# Altium schematic sheet LM2596_DC_TO_DC_schematic.SchDoc
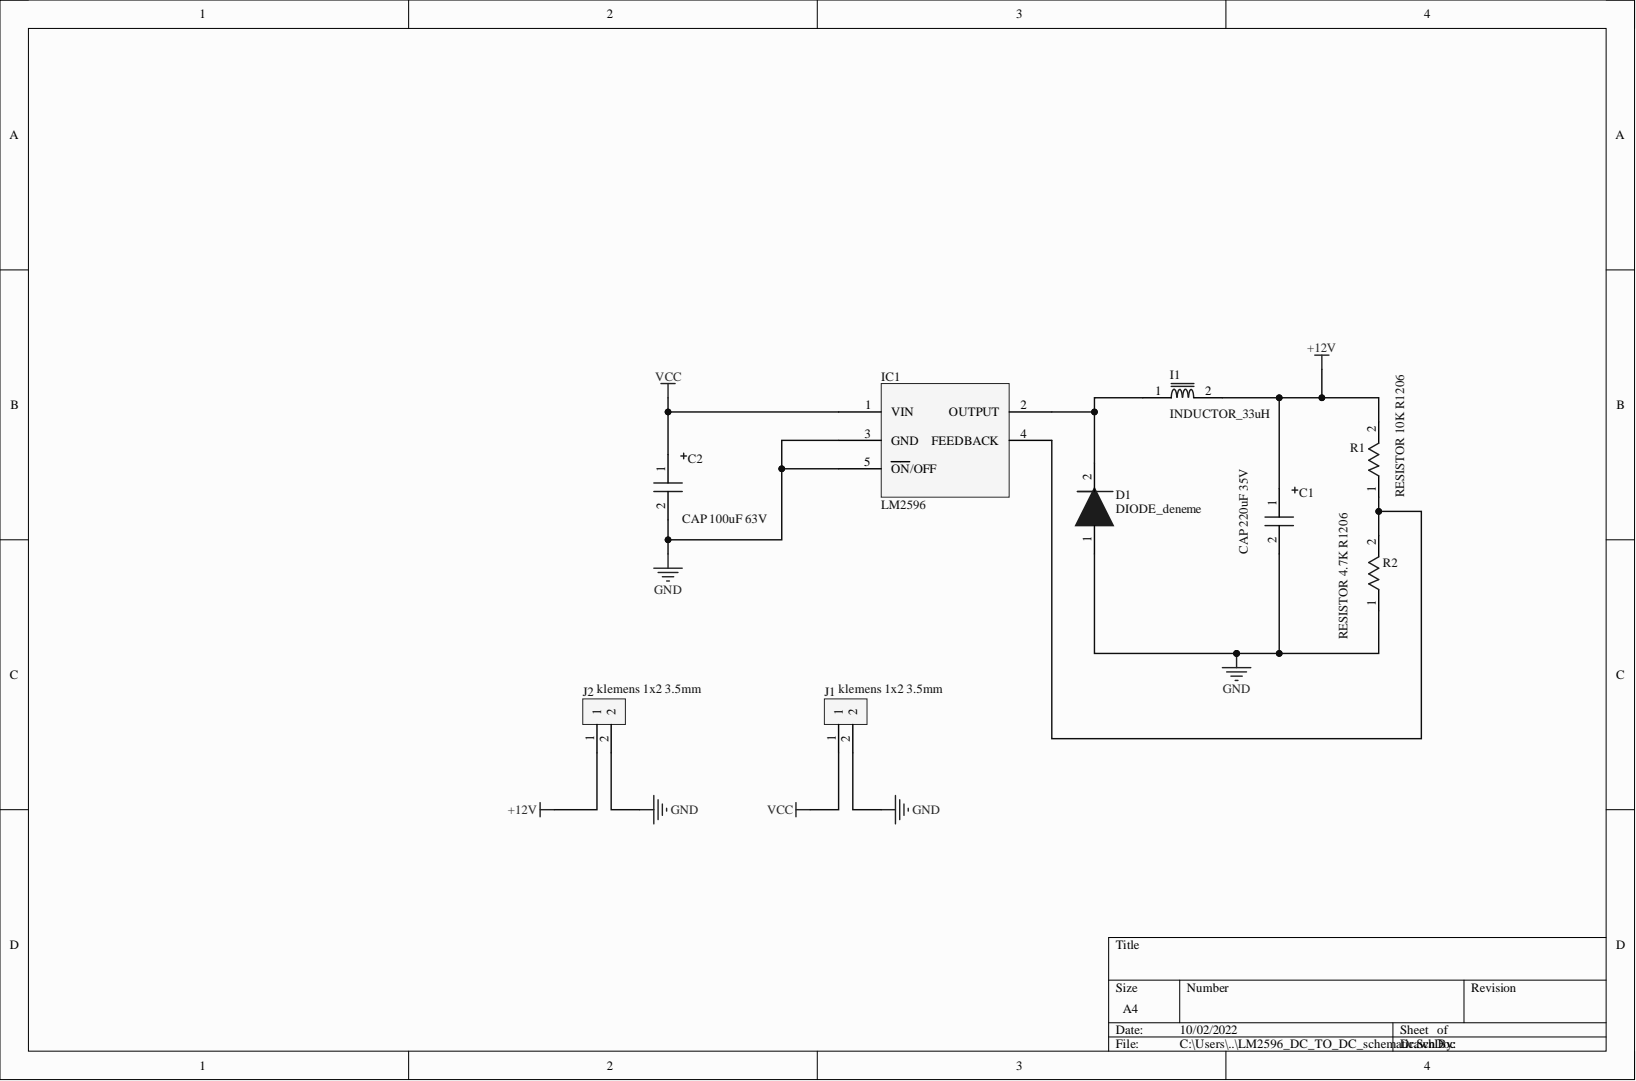
[diagram p0: LM2596_DC_TO_DC_schematic.SchDoc]

## Parts
C1  CAP 220uF 35V  [CAPACITOR]
C2  CAP 100uF 63V  [CAPACITOR]
D1  DIODE_deneme  [DIODE_deneme]
I1  INDUCTOR_33uH  [SRR1280_Inductor]
IC1  LM2596  [D2PAK]
J1  klemens 1x2 3.5mm  [klemens 1x2 3.5mm]
J2  klemens 1x2 3.5mm  [klemens 1x2 3.5mm]
R1  RESISTOR 10K R1206  [R1206 RESISTER]
R2  RESISTOR 4.7K R1206  [R1206 RESISTER]

## Nets
+12V: C1.1 I1.2 J2.1 R1.2
GND: C1.2 C2.2 D1.1 IC1.3(GND) IC1.5(ON/OFF) J1.2 J2.2 R2.1
VCC: C2.1 IC1.1(VIN) J1.1
NetD1_2: D1.2 I1.1 IC1.2(OUTPUT)
NetIC1_4: IC1.4(FEEDBACK) R1.1 R2.2

## Library data of the parts
C2 = CAP 100uF 63V: CAPACITOR; Component Kind: Standard; Library Name: LM2596_DC_TO_DC_Schematiclibrary1.SchLib
D1 = DIODE_deneme: diode deneme yaptık kafamıza göre; Component Kind: Standard; Library Name: LM2596_DC_TO_DC_Schematiclibrary1.SchLib
I1 = INDUCTOR_33uH: INDUCTOR_33uH_SRR1280; Component Kind: Standard; Library Name: LM2596_DC_TO_DC_Schematiclibrary1.SchLib
IC1 = LM2596: REGULATOR  DC TO DC ,DUCK CONVERTER; Component Kind: Standard; Library Name: LM2596_DC_TO_DC_Schematiclibrary1.SchLib
R1 = RESISTOR 10K R1206: R1206 RESISTER; Component Kind: Standard; Library Name: LM2596_DC_TO_DC_Schematiclibrary1.SchLib
R2 = RESISTOR 4.7K R1206: R1206 RESISTER; Component Kind: Standard; Library Name: LM2596_DC_TO_DC_Schematiclibrary1.SchLib
C1 = CAP 220uF 35V: Component Kind: Standard; Library Name: LM2596_DC_TO_DC_Schematiclibrary1.SchLib
J1 J2 = klemens 1x2 3.5mm: Component Kind: Standard; Description: Klemens 1x2 3.5mm; Library Name: LM2596_DC_TO_DC_Schematiclibrary1.SchLib
